# Altium schematic sheet memory.SchDoc
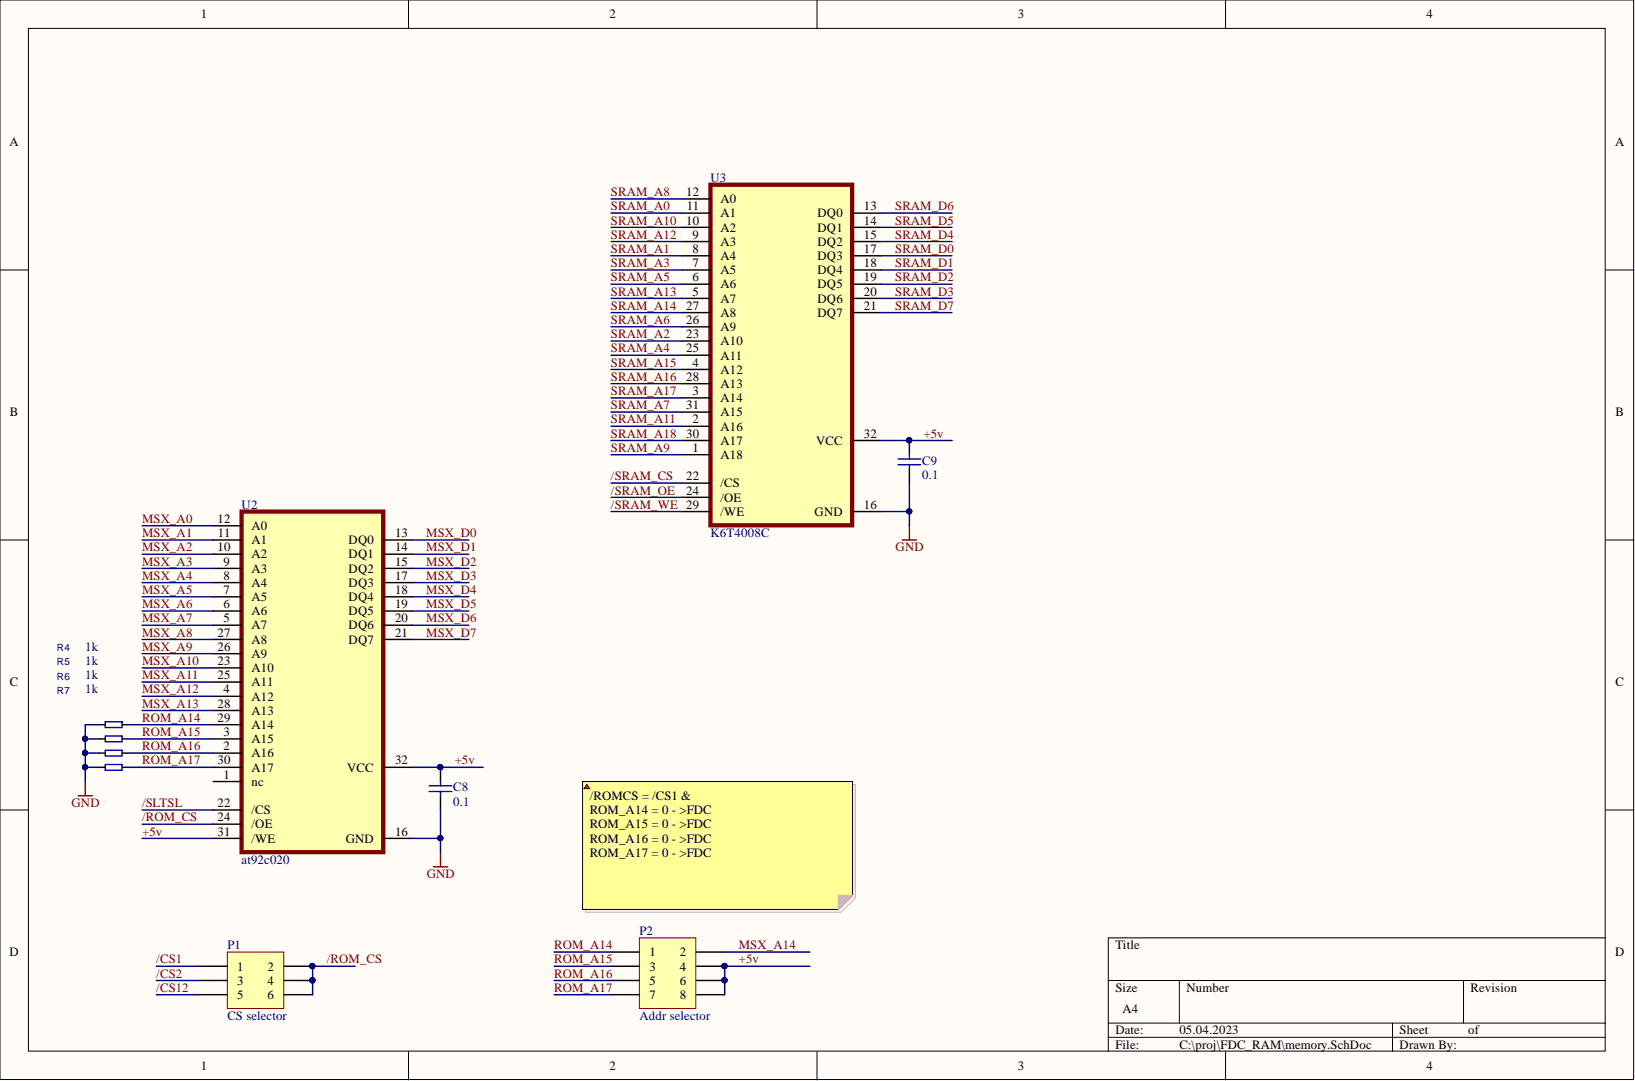
[diagram p0: memory.SchDoc]

## Parts
C8  value 0.1  [0603_cap]  (hidden comment: CAP_0.1uF_0603)
C9  value 0.1  [0603_cap]  (hidden comment: CAP_0.1uF_0603)
P1  CS selector  [HDR2X3]
P2  Addr selector  [HDR2X4]
R4  1k  [0603_RESISTOR]
R5  1k  [0603_RESISTOR]
R6  1k  [0603_RESISTOR]
R7  1k  [0603_RESISTOR]
U2  at92c020  [at29c020]
U3  K6T4008C  [SOIC127P1407X299-32N]

## Nets
GND: C8.1 C9.1 R4.1 R5.1 R6.1 R7.1 U2.16(GND) U3.16(GND)
+5v: C8.2 C9.2 P2.4 P2.6 P2.8 U2.31(/WE) U2.32(VCC) U3.32(VCC)
/CS1: P1.1
/CS2: P1.3
/CS12: P1.5
/ROM_CS: P1.2 P1.4 P1.6 U2.24(/OE)
/SLTSL: U2.22(/CS)
/SRAM_CS: U3.22(/CS)
/SRAM_OE: U3.24(/OE)
/SRAM_WE: U3.29(/WE)
MSX_A0: U2.12(A0)
MSX_A1: U2.11(A1)
MSX_A2: U2.10(A2)
MSX_A3: U2.9(A3)
MSX_A4: U2.8(A4)
MSX_A5: U2.7(A5)
MSX_A6: U2.6(A6)
MSX_A7: U2.5(A7)
MSX_A8: U2.27(A8)
MSX_A9: U2.26(A9)
MSX_A10: U2.23(A10)
MSX_A11: U2.25(A11)
MSX_A12: U2.4(A12)
MSX_A13: U2.28(A13)
MSX_A14: P2.2
MSX_D0: U2.13(DQ0)
MSX_D1: U2.14(DQ1)
MSX_D2: U2.15(DQ2)
MSX_D3: U2.17(DQ3)
MSX_D4: U2.18(DQ4)
MSX_D5: U2.19(DQ5)
MSX_D6: U2.20(DQ6)
MSX_D7: U2.21(DQ7)
ROM_A14: P2.1 R4.2 U2.29(A14)
ROM_A15: P2.3 R5.2 U2.3(A15)
ROM_A16: P2.5 R6.2 U2.2(A16)
ROM_A17: P2.7 R7.2 U2.30(A17)
SRAM_A0: U3.11(A1)
SRAM_A1: U3.8(A4)
SRAM_A2: U3.23(A10)
SRAM_A3: U3.7(A5)
SRAM_A4: U3.25(A11)
SRAM_A5: U3.6(A6)
SRAM_A6: U3.26(A9)
SRAM_A7: U3.31(A15)
SRAM_A8: U3.12(A0)
SRAM_A9: U3.1(A18)
SRAM_A10: U3.10(A2)
SRAM_A11: U3.2(A16)
SRAM_A12: U3.9(A3)
SRAM_A13: U3.5(A7)
SRAM_A14: U3.27(A8)
SRAM_A15: U3.4(A12)
SRAM_A16: U3.28(A13)
SRAM_A17: U3.3(A14)
SRAM_A18: U3.30(A17)
SRAM_D0: U3.17(DQ3)
SRAM_D1: U3.18(DQ4)
SRAM_D2: U3.19(DQ5)
SRAM_D3: U3.20(DQ6)
SRAM_D4: U3.15(DQ2)
SRAM_D5: U3.14(DQ1)
SRAM_D6: U3.13(DQ0)
SRAM_D7: U3.21(DQ7)

Not connected: U2 1(nc)

## Library data of the parts
C8 C9 = CAP_0.1uF_0603: CAP 0.1uF 63V CERAMIC X7R 0603; Manufacturer: Kemet; PartNumber: C0603C104K3RACTU; Component Kind: Standard; Datasheet link: http://www.kemet.com/kemet/web/homepage/kechome.nsf/vapubfilesname/F3102TA.pdf/$file/F3102TA.pdf; DigiKeyPartNumber: 399-1281-2-ND; Manufacturer URL: http://www.kemet.com/; RoHS: 1; Library Name: fdc_ram.SchLib
P1 = Header 3X2: Header, 3-Pin, Dual row; Component Kind: Standard; LatestRevisionDate: 17-Jul-2002; LatestRevisionNote: Re-released for DXP Platform.; Library Name: Miscellaneous Connectors.IntLib; Signal Integrity: Connector; Publisher: Altium Limited
P2 = Header 4X2: Header, 4-Pin, Dual row; Component Kind: Standard; LatestRevisionDate: 17-Jul-2002; LatestRevisionNote: Re-released for DXP Platform.; Library Name: Miscellaneous Connectors.IntLib; Signal Integrity: Connector; Publisher: Altium Limited
R4-R7 = R-1k-0603: Resistor 1k 0603; Manufacturer: Generic; Rating: 63mW; Tolerance: 1%; Component Kind: Standard; value: 1k; Library Name: sunrise.SchLib
U2 = at92c020: flash - IC 512K x 8_ Parallel 55ns 32-DIP; MF: Alliance Memory,; MP: AS6C1008-55SIN; Availability: Unavailable; Component Kind: Standard; Package: SOP-32 Alliance Memory; Price: None; SnapEDA_Link: https://www.snapeda.com/parts/AS6C1008-55SIN/Alliance%20Memory,%20Inc./view-part/31744/?ref=snap; Library Name: fdc_ram.SchLib
U3 = K6T4008C: SRAM - Asynchronous Memory IC 512K x 8_ Parallel 55ns 32-SOP; MF: Alliance Memory,; MP: AS6C1008-55SIN; Availability: Unavailable; Component Kind: Standard; Package: SOP-32 Alliance Memory; Price: None; SnapEDA_Link: https://www.snapeda.com/parts/AS6C1008-55SIN/Alliance%20Memory,%20Inc./view-part/31744/?ref=snap; Library Name: fdc_ram.SchLib
